# Altium schematic sheet 传感器.SchDoc
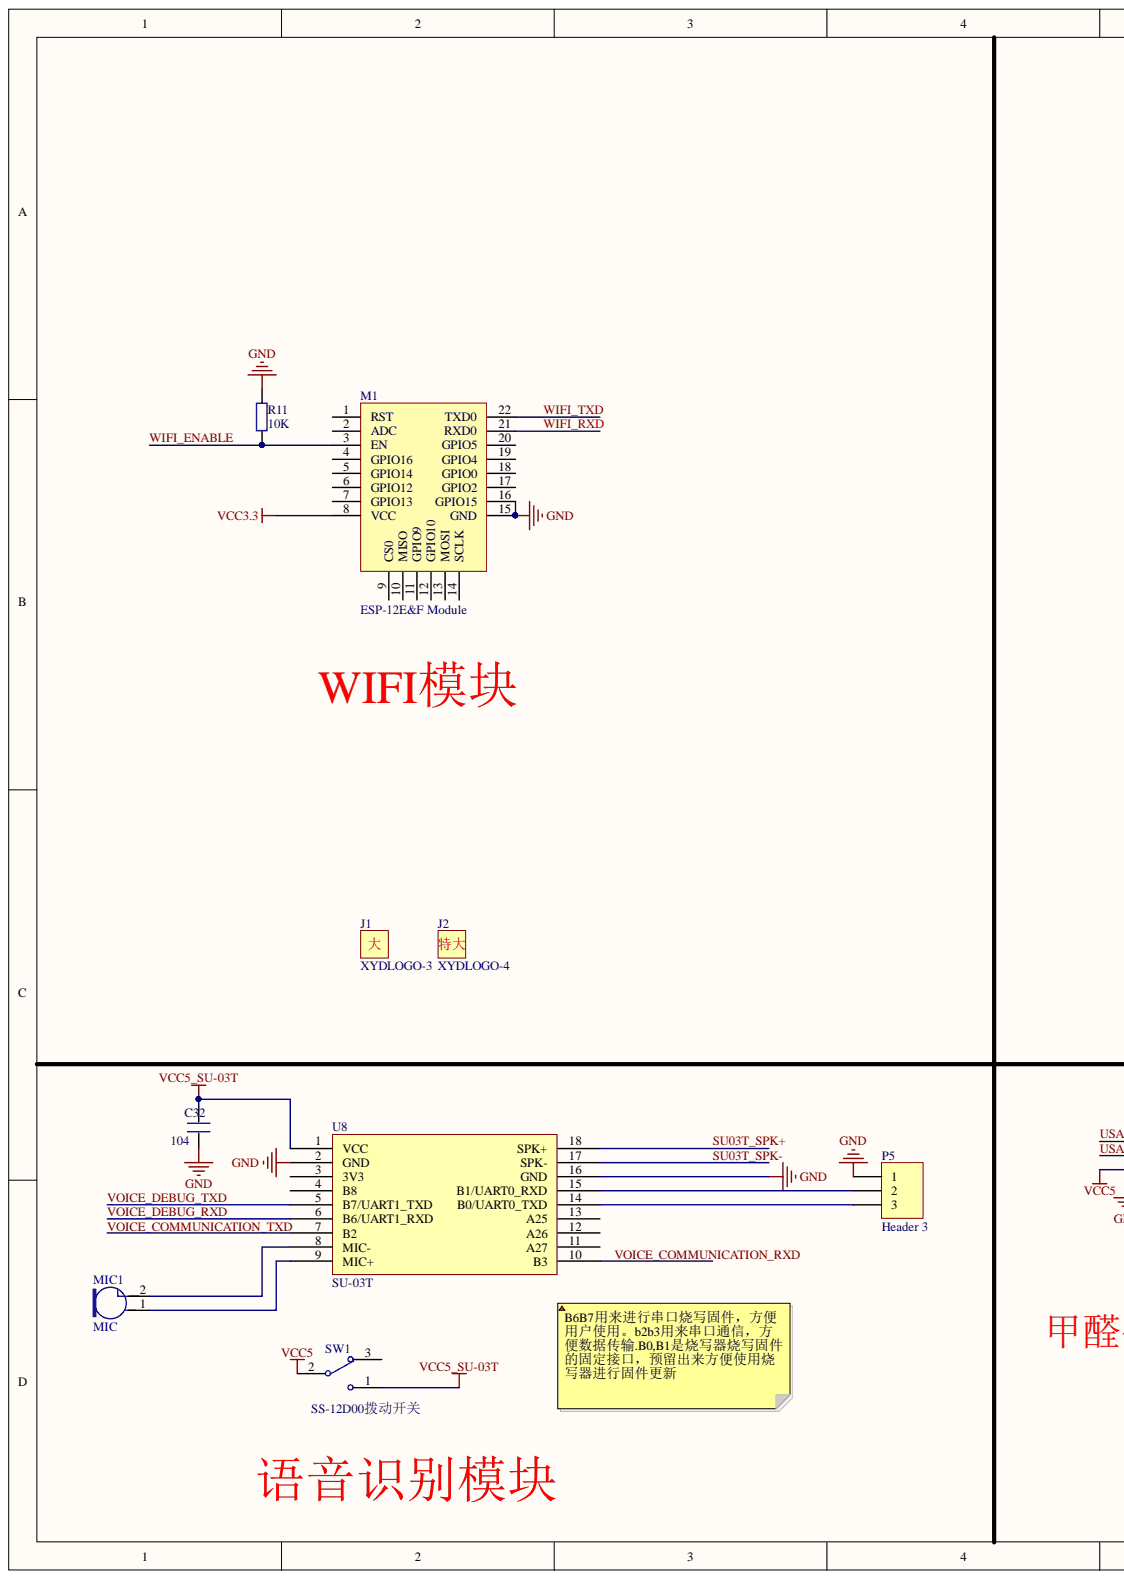
[diagram p0: 传感器.SchDoc, left]
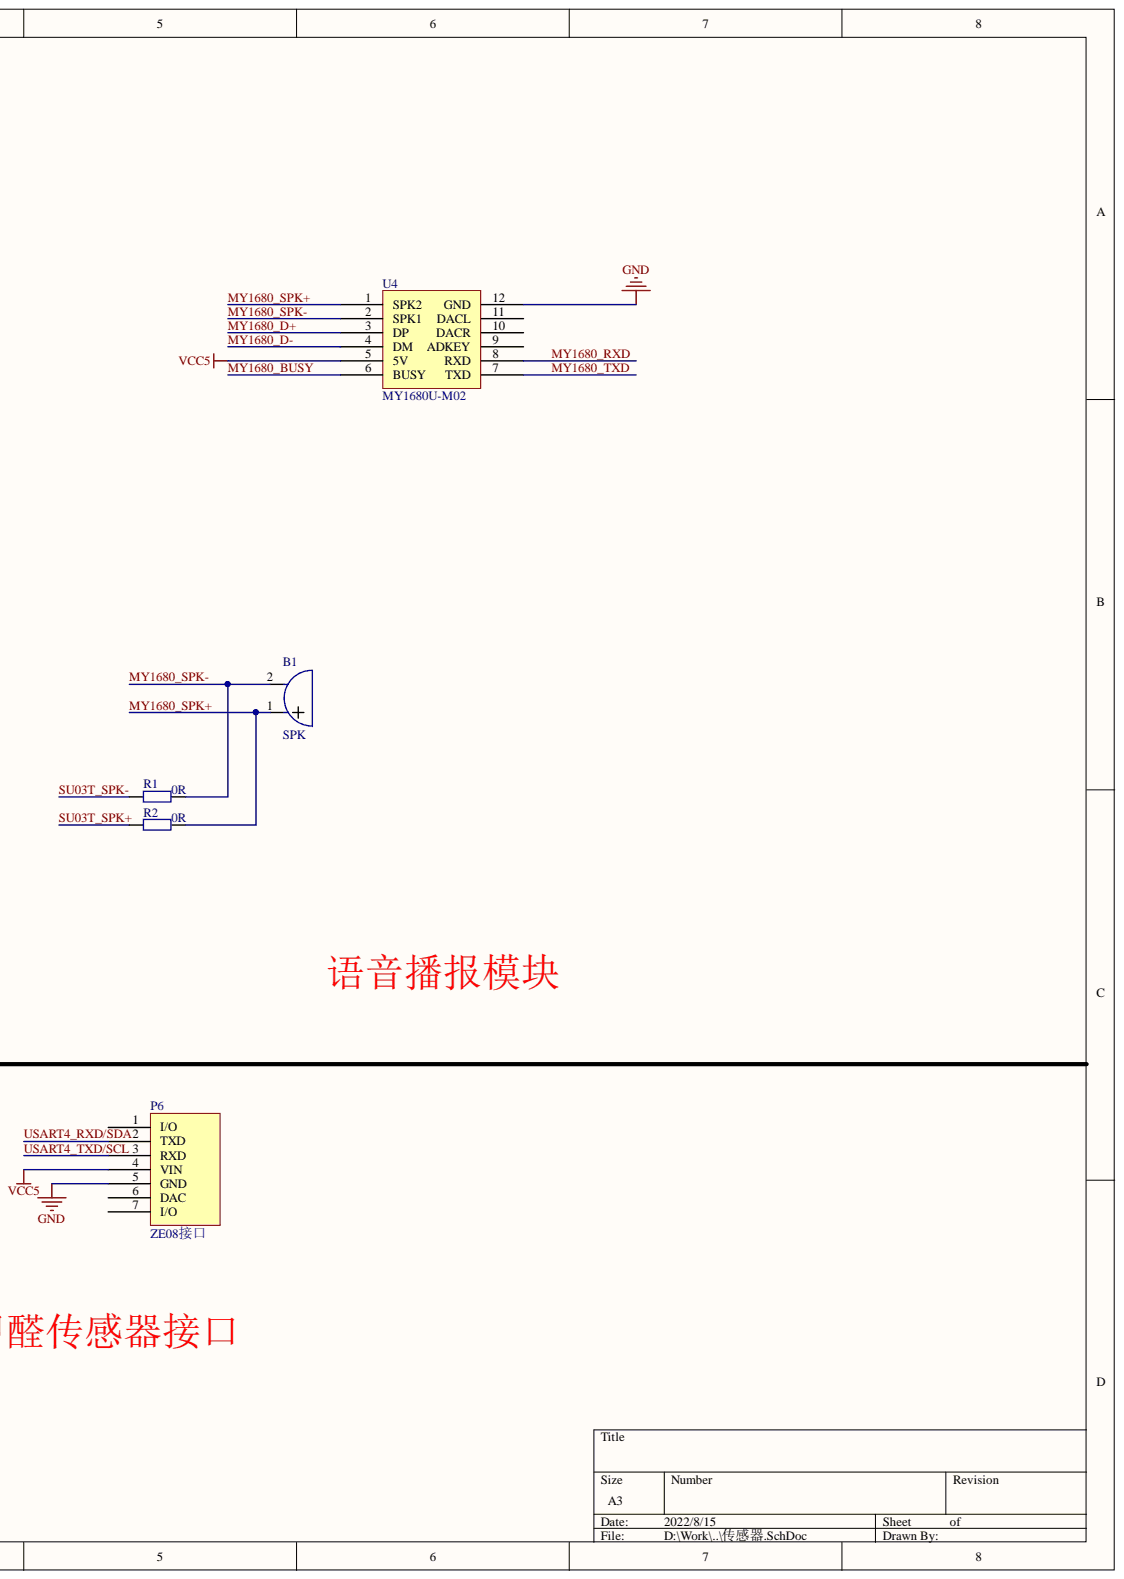
[diagram p1: 传感器.SchDoc, right]

## Parts
B1  SPK  [CONN-TH_P2.00_HX20007-2A]
C32  value 104  [0603C]  (hidden comment: CAP)
J1  XYDLOGO-3  [XYDLOGO1]
J2  XYDLOGO-4  [XYDLOGO2]
M1  ESP-12E&F Module  [ESP-12F]
MIC1  MIC  [MIC]
P5  Header 3  [HDR1X3]
P6  ZE08接口  [CONN-SMD_M1250VS-07P]
R1  value 0R  [0603R]  (hidden comment: Res2)
R2  value 0R  [0603R]  (hidden comment: Res2)
R11  value 10K  [0603R]  (hidden comment: Res2)
SW1  SS-12D00拨动开关  [开关]
U4  MY1680U-M02  [MY1680U-M02]
U8  SU-03T  [SU-03T SMD]

## Nets
GND: C32.2 M1.15(GND) M1.16(GPIO15) P5.1 P6.5(GND) R11.1 U4.12(GND) U8.2(GND) U8.16(GND)
VCC3.3: M1.8(VCC)
VCC5: P6.4(VIN) SW1.2 U4.5(5V)
VCC5_SU-03T: C32.1 SW1.1 U8.1(VCC)
MY1680_BUSY: U4.6(BUSY)
MY1680_D+: U4.3(DP)
MY1680_D-: U4.4(DM)
MY1680_RXD: U4.8(RXD)
MY1680_SPK+: B1.1 R2.2 U4.1(SPK2)
MY1680_SPK-: B1.2 R1.2 U4.2(SPK1)
MY1680_TXD: U4.7(TXD)
SU03T_SPK+: R2.1 U8.18(SPK+)
SU03T_SPK-: R1.1 U8.17(SPK-)
USART4_RXD/SDA: P6.2(TXD)
USART4_TXD/SCL: P6.3(RXD)
VOICE_COMMUNICATION_RXD: U8.10(B3)
VOICE_COMMUNICATION_TXD: U8.7(B2)
VOICE_DEBUG_RXD: U8.6(B6/UART1_RXD)
VOICE_DEBUG_TXD: U8.5(B7/UART1_TXD)
WIFI_ENABLE: M1.3(EN) R11.2
WIFI_RXD: M1.21(RXD0)
WIFI_TXD: M1.22(TXD0)
NetMIC1_1: MIC1.1 U8.9(MIC+)
NetMIC1_2: MIC1.2 U8.8(MIC-)
NetP5_2: P5.2 U8.15(B1/UART0_RXD)
NetP5_3: P5.3 U8.14(B0/UART0_TXD)

Not connected: M1 1(RST) 2(ADC) 4(GPIO16) 5(GPIO14) 6(GPIO12) 7(GPIO13) 9(CS0) 10(MISO) 11(GPIO9) 12(GPIO10) 13(MOSI) 14(SCLK) 17(GPIO2) 18(GPIO0) 19(GPIO4) 20(GPIO5); P6 1(I/O) 6(DAC) 7(I/O); SW1 3; U4 9(ADKEY) 10(DACR) 11(DACL); U8 3(3V3) 4(B8) 11(A27) 12(A26) 13(A25)

## Library data of the parts
B1 = BUZZER: Component Kind: Standard; Library Name: 机器人.SchLib
MIC1 = MIC: 咪头; Component Kind: Standard; Library Name: 语音管家模块.SCHLIB
P5 = Header 3: Header, 3-Pin; Component Kind: Standard; LatestRevisionDate: 17-Jul-2002; LatestRevisionNote: Re-released for DXP Platform.; Library Name: Miscellaneous Connectors.IntLib; Signal Integrity: Connector; Publisher: Altium Limited
R1 R2 R11 = Res2: Resistor; Component Kind: Standard; LatestRevisionDate: 17-Jul-2002; LatestRevisionNote: Re-released for DXP Platform.; PackageDescription: Axial Device, Thru-Hole; 2 Leads; 0.4 in Pin Spacing; PackageReference: AXIAL-0.4; Published: 8-Jun-2000; Library Name: 常用器件库.IntLib; Publisher: Altium Limited
SW1 = SK-12D07VG4(1P2T): Component Kind: Standard; LatestRevisionDate: 17-Jul-2002; LatestRevisionNote: Re-released for DXP Platform.; Library Name: Basic.SchLib; Publisher: Altium Limited
U4 = MY1680U-M02: 语音播报模块，邮票孔封装; Component Kind: Standard; Library Name: WIFI气象站.SchLib
U8 = SU-03T: 离线语音识别模块; Component Kind: Standard; Library Name: WIFI气象站.SchLib
C32 = CAP: Component Kind: Standard; Description: CAP; Library Name: 主控端最小系统.SCHLIB
J1 = XYDLOGO-3: Component Kind: Standard; Library Name: 常用器件原理图库.SCHLIB
J2 = XYDLOGO-4: Component Kind: Standard; Library Name: 常用器件原理图库.SCHLIB
M1 = ESP-12E&F Module: Component Kind: Standard; Description: ESP-12E&F Module; Library Name: ESP-12E&F Module.SchLib
P6 = ZE08接口: Component Kind: Standard; Library Name: 甲醛检测仪原理图库.SchLib
